# Altium schematic sheet 兜售机器人V03.SchDoc
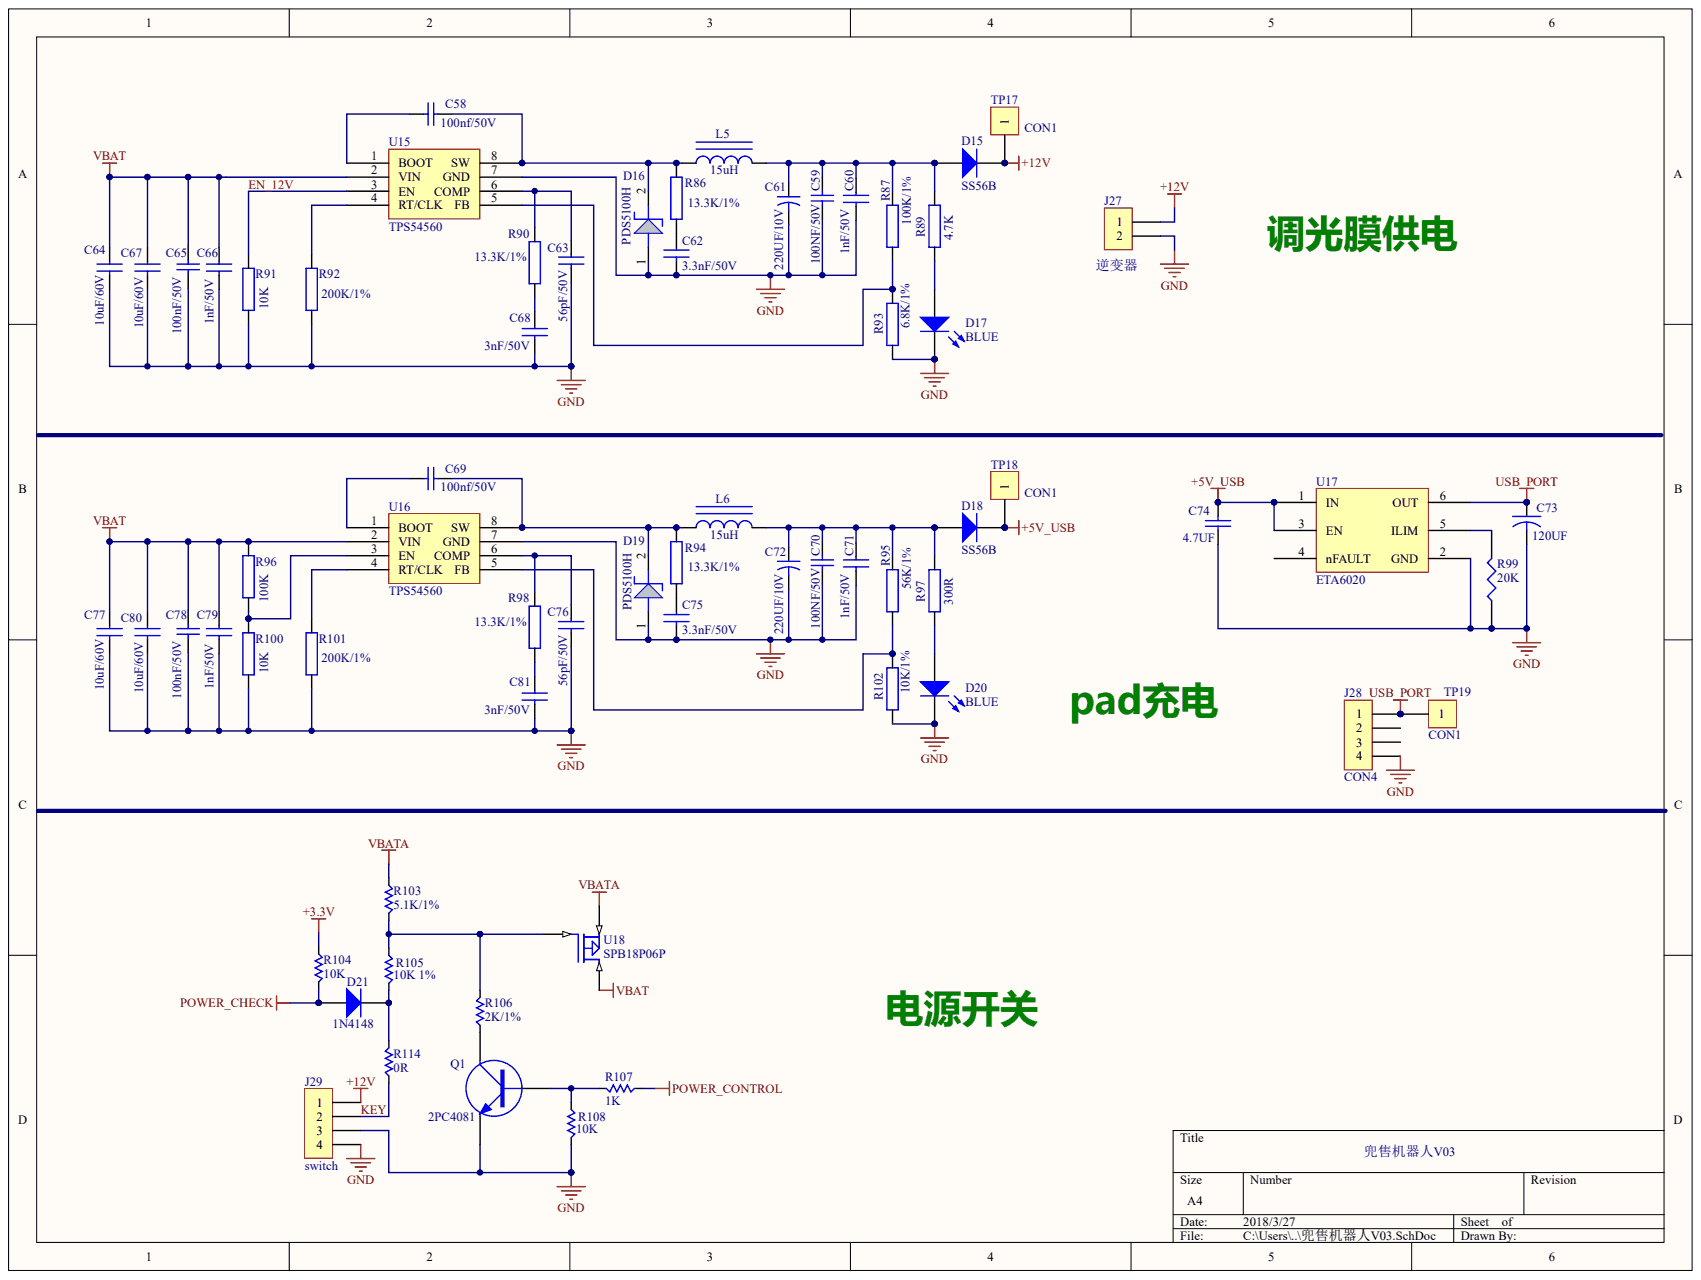
[diagram p0: 兜售机器人V03.SchDoc]

## Parts
C58  100nf/50V
C59  100NF/50V
C60  1nF/50V
C61  220UF/10V
C62  3.3nF/50V
C63  56pF/50V
C64  10uF/60V
C65  100nF/50V
C66  1nF/50V
C67  10uF/60V
C68  3nF/50V
C69  100nf/50V
C70  100NF/50V
C71  1nF/50V
C72  220UF/10V
C73  120UF
C74  4.7UF
C75  3.3nF/50V
C76  56pF/50V
C77  10uF/60V
C78  100nF/50V
C79  1nF/50V
C80  10uF/60V
C81  3nF/50V
D15  SS56B
D16  PDS5100H
D17  BLUE
D18  SS56B
D19  PDS5100H
D20  BLUE
D21  1N4148
J27  逆变器
J28  CON4
J29  switch
L5  15uH
L6  15uH
Q1  2PC4081
R86  13.3K/1%
R87  100K/1%
R89  4.7K
R90  13.3K/1%
R91  10K
R92  200K/1%
R93  6.8K/1%
R94  13.3K/1%
R95  56K/1%
R96  100K
R97  300R
R98  13.3K/1%
R99  20K
R100  10K
R101  200K/1%
R102  10K/1%
R103  5.1K/1%
R104  10K
R105  10K 1%
R106  2K/1%
R107  1K
R108  10K
R114  0R
TP17  CON1
TP18  CON1
TP19  CON1
U15  TPS54560
U16  TPS54560
U17  ETA6020
U18  SPB18P06P

## Nets
+3.3V: R104.2
+5V_USB: C74.1 D18.2 TP18.1 U17.1(IN) U17.3(EN)
+12V: D15.2 J27.1 J29.1 TP17.1
GND: C59.2 C60.2 C61.2 C62.2 C63.2 C64.2 C65.2 C66.2 C67.2 C68.2 C70.2 C71.2 C72.2 C73.2 C74.2 C75.2 C76.2 C77.2 C78.2 C79.2 C80.2 C81.2 D16.1 D17.2 D19.1 D20.2 J27.2 J28.4 J29.3 J29.4 Q1.2 R91.2 R92.2 R93.1 R99.1 R100.2 R101.2 R102.1 R108.1 U15.7(GND) U16.7(GND) U17.2(GND)
POWER_CHECK: D21.1 R104.1
POWER_CONTROL: R107.2
USB_PORT: C73.1 J28.1 TP19.1 U17.6(OUT)
VBAT: C64.1 C65.1 C66.1 C67.1 C77.1 C78.1 C79.1 C80.1 R96.1 U15.2(VIN) U16.2(VIN) U18.2
VBATA: R103.2 U18.3
EN_12V: R91.1 U15.3(EN)
KEY: J29.2 R114.2
NetC58_1: C58.1 U15.1(BOOT)
NetC58_2: C58.2 D16.2 L5.1 R86.2 U15.8(SW)
NetC59_1: C59.1 C60.1 C61.1 D15.1 L5.2 R87.2 R89.2
NetC62_1: C62.1 R86.1
NetC63_1: C63.1 R90.2 U15.6(COMP)
NetC68_1: C68.1 R90.1
NetC69_1: C69.1 U16.1(BOOT)
NetC69_2: C69.2 D19.2 L6.1 R94.2 U16.8(SW)
NetC70_1: C70.1 C71.1 C72.1 D18.1 L6.2 R95.2 R97.2
NetC75_1: C75.1 R94.1
NetC76_1: C76.1 R98.2 U16.6(COMP)
NetC81_1: C81.1 R98.1
NetD17_1: D17.1 R89.1
NetD20_1: D20.1 R97.1
NetD21_2: D21.2 R105.1 R114.1
NetQ1_1: Q1.1 R107.1 R108.2
NetQ1_3: Q1.3 R106.1
NetR87_1: R87.1 R93.2 U15.5(FB)
NetR92_1: R92.1 U15.4(RT/CLK)
NetR95_1: R95.1 R102.2 U16.5(FB)
NetR96_2: R96.2 R100.1 U16.3(EN)
NetR99_2: R99.2 U17.5(ILIM)
NetR101_1: R101.1 U16.4(RT/CLK)
NetR103_1: R103.1 R105.2 R106.2 U18.1
N00192:
N00193:
兜售机器人V03:

Not connected: J28 2 3; U17 4(nFAULT)

## Library data of the parts
C58 C65 C69 C78 = (no symbol name): 材质: X7R; 名称: 贴片电容; : 50V
  C58 C69: value: 100nf/50V
  C65 C78: value: 100nF/50V
C59 C70 = (no symbol name): 材质: X7R; 名称: 贴片电容; value: 100nf/0603/X7R/50V; : 50V
C60 C62 C63 C66 C68 C71 C75 C76 C79 C81 = (no symbol name): Text Field1: 20; Text Field2: 10
C61 C72 = (no symbol name): Value: 100pF; Published: 23-Sep-2002; PackageReference: CAPR5-4X5; PackageDescription: Capacitor; 2 Leads; Publisher: Altium Limited
D16 D19 = (no symbol name): Text Field1: 30; Text Field2: 20
L5 L6 = (no symbol name): Text Field1: 30; Text Field2: 40; value: 15uH
R86 R87 R89-R98 R100-R102 = (no symbol name): Text Field1: 20; Text Field2: 30
C64 C67 C73 C74 C77 C80 D15 D17 D18 D20 D21 J27-J29 Q1 R99 R103-R108 R114 TP17-TP19 U15-U18 = (no symbol name)
  D15 D18 D21: Published: 8-Jun-2000; LatestRevisionDate: 17-Jul-2002; LatestRevisionNote: Re-released for DXP Platform.; PackageVersion: Sep-1996; PackageReference: SMC; PackageDescription: DO-214-AB/SMC; 2 C-Bend Leads; Body 7.9 x 5.9 mm, inc. leads (LxW); Code_JEDEC: DO-214-AB
  D17 D20: Published: 8-Jun-2000; LatestRevisionDate: 17-Dec-2003; LatestRevisionNote: PCB Footprint replaced.; DatasheetVersion: 16-Apr-2002; PackageVersion: 16-Apr-2002; PackageReference: 3.5X2.8X1.9; PackageDescription: SM LED; 2 C-Bend Leads; Body 3.5 x 2.8 x 1.9 mm, inc leads (LxWxH typ)
  D15 D17 D18 D20 D21: Publisher: Altium Limited
